# Altium schematic sheet Sheet.SchDoc(Sheet)
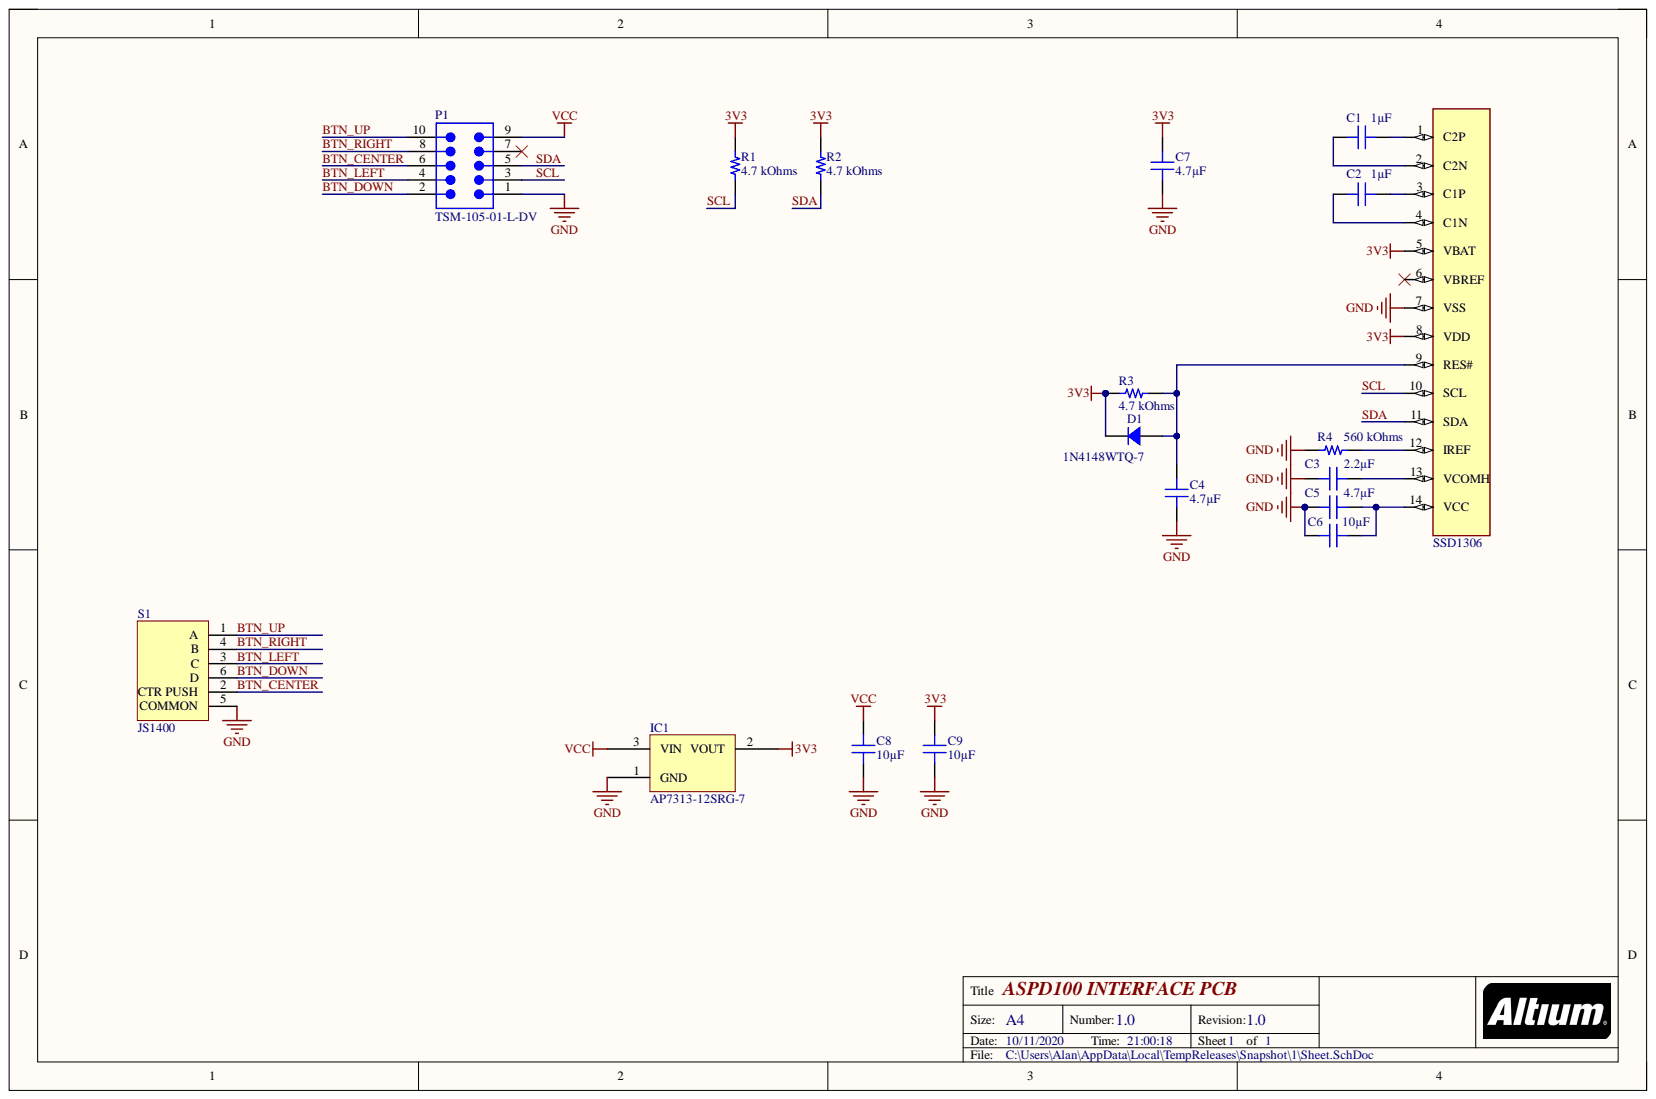
[diagram p0: Sheet.SchDoc]

## Parts
C1  1µF  [CAP 0603_1608]
C2  1µF  [CAP 0603_1608]
C3  2.2µF  [CAP 0603_1608]
C4  4.7µF  [CAP 0603_1608]
C5  4.7µF  [CAP 0603_1608]
C6  10µF  [CAP 0603_1608]
C7  4.7µF  [CAP 0603_1608]
C8  10µF  [CAP 0603_1608]
C9  10µF  [CAP 0603_1608]
D1  1N4148WTQ-7  [SOD-523]
IC1  AP7313-12SRG-7  [DIODES SOT-23-3]
P1  TSM-105-01-L-DV  [SMTC-TSM-105-01-X-DV]
R1  4.7 kOhms  [RES 0603_1608]
R2  4.7 kOhms  [RES 0603_1608]
R3  4.7 kOhms  [RES 0603_1608]
R4  560 kOhms  [RES 0603_1608]
S1  JS1400  [JS1400AFQ]
  SSD1306  [OLED_SSD1306_0.91in_Folded]

## Nets
3V3: C7.2 C9.2 D1.2 IC1.2 R1.2 R2.2 R3.1 .5(VBAT) .8(VDD)
GND: C3.1 C4.1 C5.1 C6.1 C7.1 C8.1 C9.1 IC1.1 P1.1 R4.1 S1.5(COMMON) .7(VSS)
Net_1: C1.1 .1(C2P)
Net_2: C1.2 .2(C2N)
Net_3: C2.1 .3(C1P)
Net_4: C2.2 .4(C1N)
Net_6: .6(VBREF)
Net_9: C4.2 D1.1 R3.2 .9(RES#)
Net_12: R4.2 .12(IREF)
Net_13: C3.2 .13
Net_14: C5.2 C6.2 .14(VCC)
VCC: C8.2 IC1.3 P1.9
BTN_CENTER: P1.6 S1.2(CTR_PUSH)
BTN_DOWN: P1.2 S1.6(D)
BTN_LEFT: P1.4 S1.3(C)
BTN_RIGHT: P1.8 S1.4(B)
BTN_UP: P1.10 S1.1(A)
SCL: P1.3 R1.1 .10(SCL)
SDA: P1.5 R2.1 .11(SDA)

Not connected: P1 7

## Library data of the parts
C1 C2 = CC0603KRX5R5BB105: CAP CER 1UF 6.3V X5R 0603; Manufacturer: Yageo; Database Table Name: Capacitors - Ceramic - 0603; Tolerance: ±10%; Applications: General Purpose; Capacitance: 1µF; Component Kind: Standard; ComponentLink1Description: Datasheet; ComponentLink1URL: https://www.yageo.com/upload/media/product/productsearch/datasheet/mlcc/UPY-GPHC_X5R_4V-to-50V_25.pdf; ComponentLink2Description: DigiKey Link; ComponentLink2URL: http://digikey.com/product-detail/en/yageo/CC0603KRX5R5BB105/311-1447-1-ND/2833753; Device Package: 0603 (1608 Metric); LastUpdated: 02/09/2020 01:03:24; Library Name: Celestial Altium Library - altium_library.DbLib; Lifecycle Status: Active; Minimum Order: 1; Mounting Type: Surface Mount, MLCC; Operating Temperature: -55°C ~ 85°C; Package / Case: 0603 (1608 Metric); Packaging: TapeAndReel; Part Number: CC0603KRX5R5BB105; Part Status: Active; PartId: 8994; Price: 0.02; Series: CC; Size / Dimension: 0.063" L x 0.031" W (1.60mm x 0.80mm); Supplier 1: DigiKey; Supplier Part Number 1: 311-1447-1-ND; Temperature Coefficient: X5R; Thickness (Max): 0.035" (0.90mm); Value: 1µF; Voltage - Rated: 6.3V
C3 = 06036C225KAT2A: CAP CER 2.2UF 6.3V X7R 0603; Manufacturer: AVX Corporation; Database Table Name: Capacitors - Ceramic - 0603; Tolerance: ±10%; Applications: General Purpose; Capacitance: 2.2µF; Component Kind: Standard; ComponentLink1Description: Datasheet; ComponentLink1URL: http://datasheets.avx.com/X7RDielectric.pdf; ComponentLink2Description: DigiKey Link; ComponentLink2URL: http://digikey.com/product-detail/en/avx-corporation/06036C225KAT2A/478-5316-1-ND/1950983; Device Package: 0603 (1608 Metric); LastUpdated: 02/09/2020 01:03:35; Library Name: Celestial Altium Library - altium_library.DbLib; Lifecycle Status: Active; Minimum Order: 1; Mounting Type: Surface Mount, MLCC; Operating Temperature: -55°C ~ 125°C; Package / Case: 0603 (1608 Metric); Packaging: TapeAndReel; Part Number: 06036C225KAT2A; Part Status: Active; PartId: 2607; Price: 0.09; Series: -; Size / Dimension: 0.063" L x 0.032" W (1.60mm x 0.81mm); Supplier 1: DigiKey; Supplier Part Number 1: 478-5316-1-ND; Temperature Coefficient: X7R; Thickness (Max): 0.035" (0.90mm); Value: 2.2µF; Voltage - Rated: 6.3V
C4 C5 C7 = CL10B475KQ8NQNC: CAP CER 4.7UF 6.3V X7R 0603; Manufacturer: Samsung Electro-Mechanics; Database Table Name: Capacitors - Ceramic - 0603; Tolerance: ±10%; Applications: General Purpose; Capacitance: 4.7µF; Component Kind: Standard; ComponentLink1Description: Datasheet; ComponentLink1URL: http://www.samsungsem.com/kr/support/product-search/mlcc/CL10B475KQ8NQNC.jsp; ComponentLink2Description: DigiKey Link; ComponentLink2URL: http://digikey.com/product-detail/en/samsung-electro-mechanics/CL10B475KQ8NQNC/1276-2087-1-ND/3890173; Device Package: 0603 (1608 Metric); LastUpdated: 02/09/2020 01:03:14; Library Name: Celestial Altium Library - altium_library.DbLib; Lifecycle Status: Active; Minimum Order: 1; Mounting Type: Surface Mount, MLCC; Operating Temperature: -55°C ~ 125°C; Package / Case: 0603 (1608 Metric); Packaging: TapeAndReel; Part Number: CL10B475KQ8NQNC; Part Status: Active; PartId: 14046; Price: 0.02; Series: CL; Size / Dimension: 0.063" L x 0.031" W (1.60mm x 0.80mm); Supplier 1: DigiKey; Supplier Part Number 1: 1276-2087-1-ND; Temperature Coefficient: X7R; Thickness (Max): 0.035" (0.90mm); Value: 4.7µF; Voltage - Rated: 6.3V
C6 C8 C9 = CL10B106MQ8NRNC: CAP CER 10UF 6.3V X7R 0603; Manufacturer: Samsung Electro-Mechanics; Database Table Name: Capacitors - Ceramic - 0603; Tolerance: ±20%; Applications: General Purpose; Capacitance: 10µF; Component Kind: Standard; ComponentLink1Description: Datasheet; ComponentLink1URL: http://www.samsungsem.com/kr/support/product-search/mlcc/CL10B106MQ8NRNC.jsp; ComponentLink2Description: DigiKey Link; ComponentLink2URL: http://digikey.com/product-detail/en/samsung-electro-mechanics/CL10B106MQ8NRNC/1276-1948-1-ND/3890034; Device Package: 0603 (1608 Metric); LastUpdated: 02/09/2020 01:03:24; Library Name: Celestial Altium Library - altium_library.DbLib; Lifecycle Status: Active; Minimum Order: 1; Mounting Type: Surface Mount, MLCC; Operating Temperature: -55°C ~ 125°C; Package / Case: 0603 (1608 Metric); Packaging: TapeAndReel; Part Number: CL10B106MQ8NRNC; Part Status: Active; PartId: 8640; Price: 0.24; Series: CL; Size / Dimension: 0.063" L x 0.031" W (1.60mm x 0.80mm); Supplier 1: DigiKey; Supplier Part Number 1: 1276-1948-1-ND; Temperature Coefficient: X7R; Thickness (Max): 0.035" (0.90mm); Value: 10µF; Voltage - Rated: 6.3V
D1 = 1N4148WTQ-7: SWITCHING DIODE SOD523; Manufacturer: Diodes Incorporated; Current - Average Rectified (Io): 125mA; Current - Reverse Leakage @ Vr: 1µA @ 75V; Database Table Name: Diodes - Rectifiers - Single; Diode Type: Standard; Reverse Recovery Time (trr): 4ns; Speed: Small Signal =< 200mA (Io), Any Speed; Voltage - DC Reverse (Vr) (Max): 80V; Voltage - Forward (Vf) (Max) @ If: 1.25V @ 150mA; Component Kind: Standard; ComponentLink1Description: Datasheet; ComponentLink1URL: https://www.diodes.com/assets/Datasheets/ds30396.pdf; ComponentLink2Description: DigiKey Link; ComponentLink2URL: http://digikey.com/product-detail/en/diodes-incorporated/1N4148WTQ-7/1N4148WTQ-7DICT-ND/9765534; LastUpdated: 02/09/2020 01:23:19; Library Name: Celestial Altium Library - altium_library.DbLib; Lifecycle Status: Active; Minimum Order: 1; Mounting Type: Surface Mount; Operating Temperature - Junction: -65°C ~ 150°C; Package / Case: SC-79, SOD-523; Packaging: TapeAndReel; Part Number: 1N4148WTQ-7; Part Status: Active; PartId: 2782; Price: 0.04; Series: Automotive, AEC-Q101; Supplier 1: DigiKey; Supplier Device Package: SOD-523; Supplier Part Number 1: 1N4148WTQ-7DICT-ND
IC1 = AP7313-12SRG-7: IC REG LIN 1.2V 150MA SOT23R-3; Manufacturer: Diodes Incorporated; Current - Quiescent (Iq): 75µA; Current - Supply (Max): 85µA; Database Table Name: Voltage Regulators - Linear; Number of Regulators: 1; Output Configuration: Positive; Output Type: Fixed; Protection Features: Over Current, Over Temperature, Short Circuit; PSRR: 65dB (100Hz); Voltage - Input (Max): 6V; Component Kind: Standard; ComponentLink1Description: Datasheet; ComponentLink1URL: https://www.diodes.com/assets/Datasheets/AP7313.pdf; ComponentLink2Description: DigiKey Link; ComponentLink2URL: http://digikey.com/product-detail/en/diodes-incorporated/AP7313-12SRG-7/AP7313-12SRG-7DICT-ND/7605271; Current - Output: 150mA; LastUpdated: 02/09/2020 01:24:50; Library Name: Celestial Altium Library - altium_library.DbLib; Lifecycle Status: Active; Minimum Order: 1; Mounting Type: Surface Mount; Operating Temperature: -40°C ~ 85°C; Package / Case: TO-236-3, SC-59, SOT-23-3; Packaging: TapeAndReel; Part Number: AP7313-12SRG-7; Part Status: Active; Price: 0.11; Series: -; Supplier 1: DigiKey; Supplier Device Package: SOT-23R-3; Supplier Part Number 1: AP7313-12SRG-7DICT-ND; Voltage - Output (Min/Fixed): 1.2V
P1 = CMP-1024-00233-1: 0.025" SQ Post Header, Surface Mount, Vertical, -55 to 125 degC, 2.54 mm Pitch, 10-Pin, Male, RoHS; Manufacturer: Samtec; Pitch: 2.54 mm; Component Kind: Standard; ComponentLink1Description: Manufacturer URL; ComponentLink1URL: http://www.samtec.com; ComponentLink2Description: Datasheet; ComponentLink2URL: http://www.samtec.com/documents/webfiles/pdf/TSM.PDF; ComponentLink3Description: Package Specification; ComponentLink3URL: http://www.samtec.com/documents/webfiles/cpdf/TSM-1XX-XX-XXX-XX-X-XXX-X-MKT.pdf; DatasheetVersion: F-214; Library Name: Altium Content Vault; Mounting Technology: Surface Mount; Orientation: Vertical; PackageDescription: Double Row Gullwing ASM; PackageReference: TSM-105-01-X-DV; PackageVersion: DJ, 4/1991; PartNumber: TSM-105-01-L-DV; RoHS: True; Temperature Range: -55 to 125 degC
R1-R3 = CRCW06034K70JNTA: RES SMD 4.7K OHM 5% 1/10W 0603; Manufacturer: Vishay Dale; Database Table Name: Resistors - Surface Mount - 0603; Power (Watts): 0.1W, 1/10W; Temperature Coefficient: ±200ppm/°C; Component Kind: Standard; ComponentLink1Description: Datasheet; ComponentLink1URL: http://www.vishay.com/docs/20008/dcrcw.pdf; ComponentLink2Description: DigiKey Link; ComponentLink2URL: http://digikey.com/product-detail/en/vishay-dale/CRCW06034K70JNTA/541-3005-1-ND/6073648; Composition: Thick Film; Device Package: 0603 (1608 Metric); Features: Automotive AEC-Q200; Height - Seated (Max): 0.020" (0.50mm); LastUpdated: 02/09/2020 01:09:29; Library Name: Celestial Altium Library - altium_library.DbLib; Lifecycle Status: Active; Minimum Order: 1; Number of Terminations: 2; Operating Temperature: -55°C ~ 155°C; Package / Case: 0603 (1608 Metric); Packaging: TapeAndReel; Part Number: CRCW06034K70JNTA; Part Status: Active; PartId: 26170; Price: 0.01; Ratings: AEC-Q200; Resistance: 4.7 kOhms; Series: CRCW; Size / Dimension: 0.063" L x 0.033" W (1.60mm x 0.85mm); Supplier 1: DigiKey; Supplier Device Package: 0603; Supplier Part Number 1: 541-3005-1-ND; Tolerance: ±5%; Value: 4.7 kOhms
R4 = CRGP0603F560K: CRGP 0603 560K 1%; Manufacturer: TE Connectivity Passive Product; Database Table Name: Resistors - Surface Mount - 0603; Power (Watts): 0.25W, 1/4W; Temperature Coefficient: ±100ppm/°C; Component Kind: Standard; ComponentLink1Description: Datasheet; ComponentLink1URL: https://www.te.com/commerce/DocumentDelivery/DDEController?Action=srchrtrv&DocNm=9-1773463-9&DocType=DS&DocLang=English; ComponentLink2Description: DigiKey Link; ComponentLink2URL: http://digikey.com/product-detail/en/te-connectivity-passive-product/CRGP0603F560K/A130449CT-ND/8578281; Composition: Thick Film; Device Package: 0603 (1608 Metric); Features: Pulse Withstanding; Height - Seated (Max): 0.022" (0.55mm); LastUpdated: 02/09/2020 01:10:24; Library Name: Celestial Altium Library - altium_library.DbLib; Lifecycle Status: Active; Minimum Order: 1; Number of Terminations: 2; Operating Temperature: -55°C ~ 155°C; Package / Case: 0603 (1608 Metric); Packaging: TapeAndReel; Part Number: CRGP0603F560K; Part Status: Active; PartId: 5027; Price: 0.02; Resistance: 560 kOhms; Series: CRGP; Size / Dimension: 0.063" L x 0.031" W (1.60mm x 0.80mm); Supplier 1: DigiKey; Supplier Device Package: 0603; Supplier Part Number 1: A130449CT-ND; Tolerance: ±1%; Value: 560 kOhms
 = SSD1306: SSD1306 OLED 0.9 Display Pmodâ„¢ Platform Evaluation Expansion Board; MF: Digilent, Inc.; Availability: Unavailable; Component Kind: Standard; Library Name: SSD1306.IntLib; MP: SSD1306; Package: None; Price: None; SnapEDA_Link: https://www.snapeda.com/parts/SSD1306/Digilent,%20Inc./view-part/3624478/?ref=snap
S1 = JS1400: Component Kind: Standard; Library Name: JS1400.IntLib
